# Altium schematic sheet ST10_POWER.SchDoc
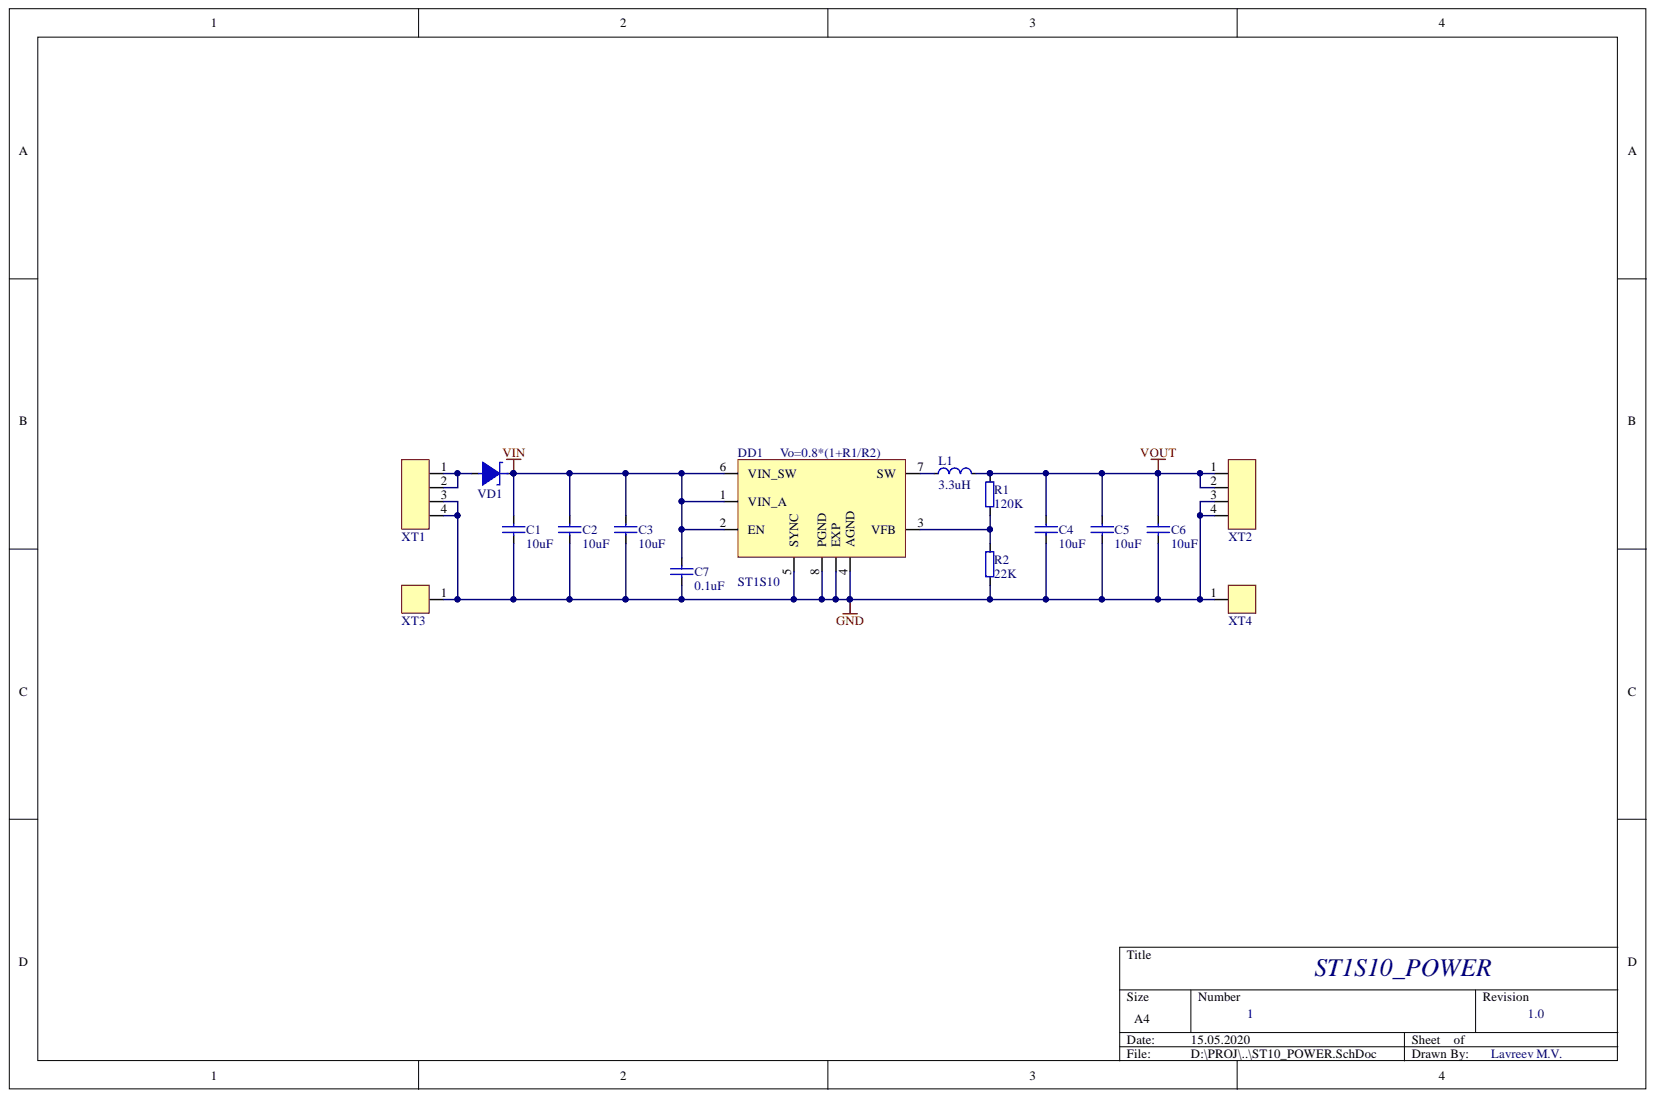
[diagram p0: ST10_POWER.SchDoc]

## Parts
DD1  ST1S10  [SO-8EPAD]
L1  3.3uH  [MSS7341]
R1  120K  [RES_0805]
R2  22K  [RES_0805]
VD1  SS24  [SMB]  (value not drawn)
XT1  (no value)  [PLS-4_1]
XT2  (no value)  [PLS-4_1]
XT3  (no value)  [PLS-1_1]
XT4  (no value)  [PLS-1_1]
С1  10uF  [CAP_CER_1206]
С2  10uF  [CAP_CER_0805]
С3  10uF  [CAP_CER_0805]
С4  10uF  [CAP_CER_0805]
С5  10uF  [CAP_CER_0805]
С6  10uF  [CAP_CER_1206]
С7  0.1uF  [CAP_CER_0805]

## Nets
GND: DD1.0(EXP) DD1.4(AGND) DD1.5(SYNC) DD1.8(PGND) R2.1 XT1.3 XT1.4 XT2.3 XT2.4 XT3.1 XT4.1 С1.1 С2.1 С3.1 С4.1 С5.1 С6.1 С7.1
VIN: DD1.1(VIN_A) DD1.2(EN) DD1.6(VIN_SW) VD1.2 С1.2 С2.2 С3.2 С7.2
VOUT: L1.1 R1.2 XT2.1 XT2.2 С4.2 С5.2 С6.2
NetDD1_3: DD1.3(VFB) R1.1 R2.2
NetDD1_7: DD1.7(SW) L1.2
NetVD1_1: VD1.1 XT1.1 XT1.2

## Library data of the parts
DD1 = ST1S10: Step-Down3 A, 900 kHz, monolithic synchronous step-down regulator IC; Manufacturer: ST; Component Kind: Standard; Library Name: IC_POWER.SchLib; Manufacturer Part Number: ST1S10
L1 = L: Inductor; Component Kind: Standard; Library Name: INDUCTOR.SchLib; Manufacturer Part Number: 3.3uH
R1 R2 = RES_SMD: Chip Resistor; Tolerance: ±5%; Component Kind: Standard; Library Name: RESISTOR.SchLib
  R1: Value: 120K
  R2: Value: 22K
VD1 = Diode Shottky: Diode; Component Kind: Standard; Library Name: DIODE.SchLib; Manufacturer Part Number: SS24
XT1 XT2 = PLS-4: Header Connector; Number of Positions: 4; Row Spacing: 2.54mm; Component Kind: Standard; Connector Type: Header; Contact Type: Male; Library Name: CONNECTOR_HEADER.SchLib; Number of Rows: 1
XT3 XT4 = PLS-1: Header Connector; Number of Positions: 1; Row Spacing: 2.54mm; Component Kind: Standard; Connector Type: Header; Contact Type: Male; Library Name: CONNECTOR_HEADER.SchLib; Number of Rows: 1
С1-С3 = CAP_CER: Fixed Ceramic Capacitor; Tolerance: ±10%; Voltage: 25V; Component Kind: Standard; Library Name: CAPACITOR.SchLib; Value: 10uF
С4-С7 = CAP_CER: Fixed Ceramic Capacitor; Tolerance: ±10%; Voltage: 10V; Component Kind: Standard; Library Name: CAPACITOR.SchLib
  С4-С6: Value: 10uF
  С7: Value: 0.1uF
